# Altium schematic sheet Block Diagram.SchDoc("Block Diagram")
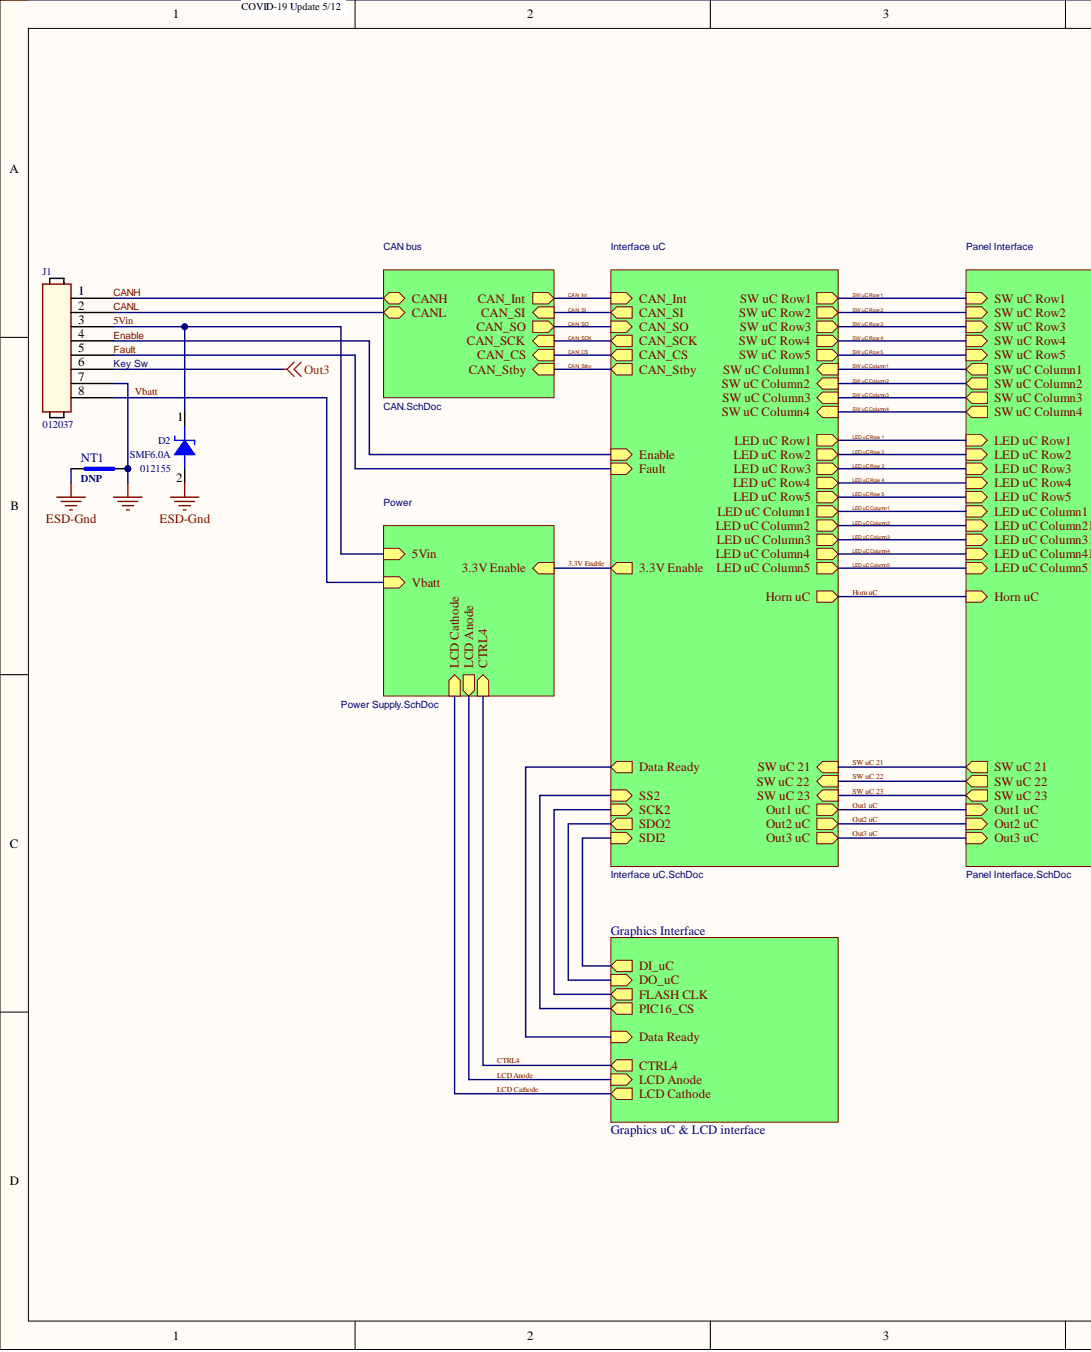
[diagram p0: Block Diagram.SchDoc, left]
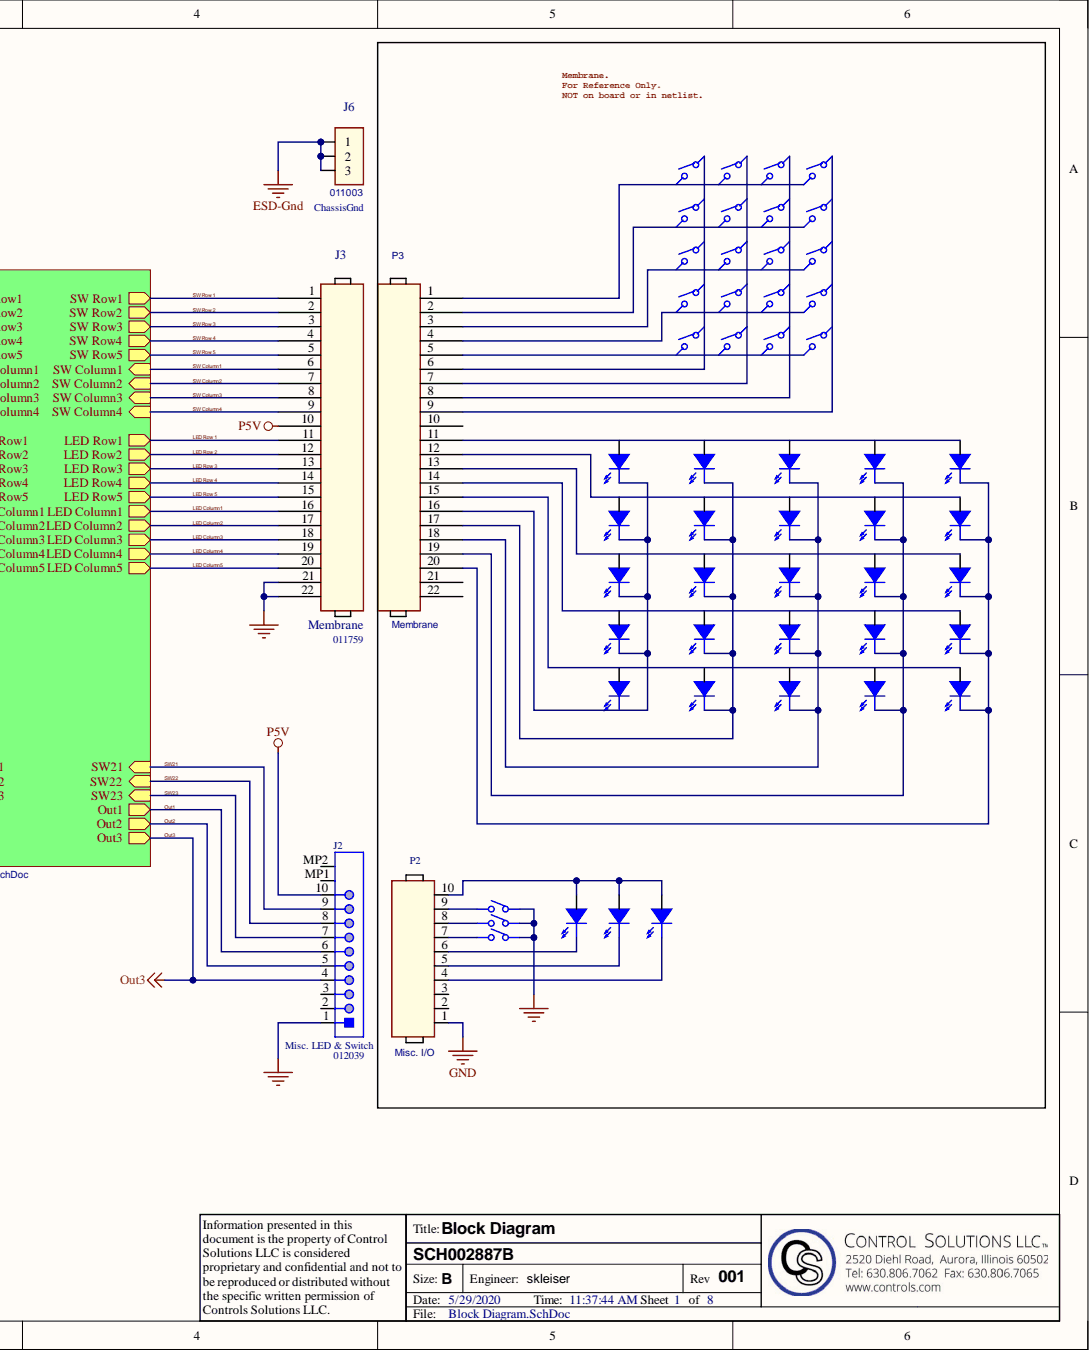
[diagram p1: Block Diagram.SchDoc, right]

## Parts
D2  SMF6.0A  [CS_IPC_SOD123_12]
J1  Controller  [B8B-PH-SM4-TB(LF)(SN)]  (value not drawn)
J2  Misc. LED & Switch  [B10B-PH-SM4-TB(LF)(SN)]
J3  Membrane  [MOLEX_522072233]
J6  ChassisGnd  [011003]
NT1  NOTE: DNP  [Res_20mil_short0603]  (hidden comment: Net_Tie_0603)

## Nets
ESD-Gnd: D2.2 J6.1 J6.2 J6.3 NT1.1
GND: J1.7 J2.1 J3.21 J3.22 NT1.2
P5V: J2.10 J3.10
5Vin: D2.1 J1.3
CANH: J1.1
CANL: J1.2
Enable: J1.4
Fault: J1.5
LED Column1: J3.16
LED Column2: J3.17
LED Column3: J3.18
LED Column4: J3.19
LED Column5: J3.20
LED Row1: J3.11
LED Row2: J3.12
LED Row3: J3.13
LED Row4: J3.14
LED Row5: J3.15
Out1: J2.6
Out2: J2.5
Out3: J1.6 J2.4
SW21: J2.9
SW22: J2.8
SW23: J2.7
SW Column1: J3.6
SW Column2: J3.7
SW Column3: J3.8
SW Column4: J3.9
SW Row1: J3.1
SW Row2: J3.2
SW Row3: J3.3
SW Row4: J3.4
SW Row5: J3.5
Vbatt: J1.8
NetJ2_2: J2.2
NetJ2_3: J2.3
NetJ2_MP1: J2.MP1
NetJ2_MP2: J2.MP2
3.3V Enable:
CAN_CS:
CAN_Int:
CAN_SCK:
CAN_SI:
CAN_SO:
CAN_Stby:
CTRL4:
Data Ready:
DI_uC:
DO_uC:
FLASH CLK:
Horn uC:
LCD Anode:
LCD Cathode:
LED uC Column1:
LED uC Column2:
LED uC Column3:
LED uC Column4:
LED uC Column5:
LED uC Row1:
LED uC Row2:
LED uC Row3:
LED uC Row4:
LED uC Row5:
Out1 uC:
Out2 uC:
Out3 uC:
PIC16_CS:
SW uC 21:
SW uC 22:
SW uC 23:
SW uC Column1:
SW uC Column2:
SW uC Column3:
SW uC Column4:
SW uC Row1:
SW uC Row2:
SW uC Row3:
SW uC Row4:
SW uC Row5:

## Library data of the parts
D2 = 012155: DIODE, TVS, 6V STANDOFF 7V BREAKDOWN 10.3V CLAMP 19.4A IPP SOD-123F RoHS; Manufacturer: Littelfuse Inc.; Database Table Name: parts; ID: 30164; Updated By: Engineer1; Component Kind: Standard; ComponentLink1Description: Datasheet; ComponentLink1URL: https://www.littelfuse.com/~/media/electronics/datasheets/tvs_diodes/littelfuse_tvs_diode_smf_datasheet.pdf.pdf; ComponentLink2Description: Supplier Part Details; ComponentLink2URL: https://www.digikey.com/products/en?keywords=F5749TR-ND; ComponentLink3Description: https://datasheet.ciiva.com/30478/0900766b81276b19-30478731.pdf?src-supplier=RSComponents; ComponentLink3URL: https://datasheet.ciiva.com/30478/0900766b81276b19-30478731.pdf?src-supplier=RSComponents; ComponentLink4Description: https://datasheet.ciiva.com/46435/1750558-46435717.pdf?src-supplier=Element14; ComponentLink4URL: https://datasheet.ciiva.com/46435/1750558-46435717.pdf?src-supplier=Element14; ComponentLink5Description: https://datasheet.ciiva.com/11193/smf5-0at1-d-11193433.pdf?src-supplier=Avnet; ComponentLink5URL: https://datasheet.ciiva.com/11193/smf5-0at1-d-11193433.pdf?src-supplier=Avnet; ComponentLink6Description: https://datasheet.ciiva.com/11194/smf5-0at1-d-11194649.pdf?src-supplier=Avnet; ComponentLink6URL: https://datasheet.ciiva.com/11194/smf5-0at1-d-11194649.pdf?src-supplier=Avnet; ComponentLink7Description: https://datasheet.ciiva.com/16487/smf5-0at1-d-16487982.pdf?src-supplier=Future+Electronics; ComponentLink7URL: https://datasheet.ciiva.com/16487/smf5-0at1-d-16487982.pdf?src-supplier=Future+Electronics; ComponentLink8Description: https://datasheet.ciiva.com/340/smf5-0at1-d-340017.pdf?src-supplier=Digi-Key; ComponentLink8URL: https://datasheet.ciiva.com/340/smf5-0at1-d-340017.pdf?src-supplier=Digi-Key; ComponentLink9Description: https://datasheet.ciiva.com/340/smf5-0at1-d-340015.pdf?src-supplier=Digi-Key; ComponentLink9URL: https://datasheet.ciiva.com/340/smf5-0at1-d-340015.pdf?src-supplier=Digi-Key; Created_Date: 2020/01/29 10:10:33; Current_Rating: 19.4A; LifeCycle: New; Manufacturer_Part_Number: SMF6.0A; MF: Littelfuse Inc.; Mfr Part Description: DIODE, TVS, 6V STANDOFF 7V BREAKDOWN 10.3V CLAMP 19.4A IPP SOD-123F ROHS; Mfr Part Number: SMF6.0A; Mfr. P/N: SMF6.0A; MP: SMF6.0A; Package_Case: SOD-123F; Part Number: 012155; Part_Type: Diode; RoHS: Yes; Sub_Type: TVS; Supplier: Digi-Key; Supplier 1: Digi-Key; Supplier Part Number: F5749TR-ND; Temperature Range: -55C to +150C (TJ); Updated_Date: 2020/04/16 11:11:00; Value: SMF6.0A; Voltage_Rating: 6V; Created By: Engineer1; Library Name: CSLLC.DbLib
J1 = 012037: Conn Header SMD Vertical 8Pos 2mm T/R RoHS; Manufacturer: JST Sales America Inc.; Manufacturer 1: JST; Mfr Part Description: CONN HEADER SMD 8POS 2MM; Part_Type: Connector; Connector Type: Male Pin; Contact Resistance: 20mR; Current Rating: 2A; Database Table Name: parts; Gender: Male; ID: 30145; Insulation Resistance: 1GR; Max Voltage Rating (AC): 100V; Number of Contacts: 8; Updated By: Engineer1; Value: B8B-PH-SM4-TB(LF)(SN); Color: Natural,White; Component Kind: Standard; ComponentLink1Description: Datasheet; ComponentLink1URL: http://www.jst-mfg.com/product/pdf/eng/ePH.pdf; ComponentLink2Description: Supplier Part Details; ComponentLink2URL: https://www.digikey.com/products/en?keywords=455-1740-2-ND; ComponentLink3Description: https://datasheet.ciiva.com/712/eph-712658.pdf?src-supplier=Digi-Key; ComponentLink3URL: https://datasheet.ciiva.com/712/eph-712658.pdf?src-supplier=Digi-Key; ComponentLink4Description: https://datasheet.ciiva.com/712/eph-712657.pdf?src-supplier=Digi-Key; ComponentLink4URL: https://datasheet.ciiva.com/712/eph-712657.pdf?src-supplier=Digi-Key; ComponentLink5Description: https://datasheet.ciiva.com/730/eph-730705.pdf?src-supplier=Digi-Key; ComponentLink5URL: https://datasheet.ciiva.com/730/eph-730705.pdf?src-supplier=Digi-Key; ComponentLink6Description: https://datasheet.ciiva.com/16288/eph-16288437.pdf?src-supplier=Future+Electronics; ComponentLink6URL: https://datasheet.ciiva.com/16288/eph-16288437.pdf?src-supplier=Future+Electronics; Contact Material: Tin,Bronze,Copper Alloy,Brass; Contact Mating Length: 0.13inch; Contact Plating: Tin,Copper; Created_Date: 2019/10/15 13:20:55; Current_Rating: 2A; Depth: 5mm; Fastening Type: Detent; Flammability Rating: UL94 V-0; Height: 9.4mm; Housing Color: Beige; Housing Material: Polyamide; Lead Free: Lead Free; Lead Pitch: 2mm; Length: 19.95mm; LifeCycle: New; Manufacturer Part Number 1: B8B-PH-SM4-TB(LF)(SN); Max Current Rating: 2A; Max Operating Temperature: 85°C; Mfr Part Number: B8B-PH-SM4-TB(LF)(SN); Mfr. P/N: B8B-PH-SM4-TB(LF)(SN); Min Operating Temperature: -25°C; Mount: Surface Mount; Number of Poles: 8; Number of Positions: 8; Number of Rows: 1; Orientation: Straight; Packaging: Tape and Reel; Part Number: 012037; Pitch: 2mm; Pricing 1: 1=0.28900, 10=0.28900, 25=0.28900, 50=0.28900, 100=0.28900, 250=0.28900, 500=0.28900, 1000=0.28900 (USD); REACH SVHC: No SVHC; RoHS: Yes; Stock 1: 6123; Supplier: Digi-Key; Supplier 1: Newark; Supplier Part Number: 455-1740-2-ND; Supplier Part Number 1: 38K0010; Temperature Range: -25C to +85C; Termination: Solder; Updated_Date: 2019/10/24 09:56:43; Voltage Rating: 100V; Voltage Rating (AC): 100V; Voltage_Rating: 100V; Width: 5.5mm; Working Voltage: 100V; Created By: Engineer1; Library Name: CSLLC.DbLib
J2 = 012039: CONN HEADER SMD 10POS 2MM; Manufacturer: JST Sales America Inc.; Mfr Part Description: Connector Header Surface Mount 10 position 0.079" (2.00mm); Part_Type: Connector; Current_Rating: 2A; Database Table Name: parts; ID: 30146; Updated By: Engineer1; Value: B10B-PH-SM4-TB(LF)(SN); Voltage_Rating: 100V; Component Kind: Standard; ComponentLink1Description: Datasheet; ComponentLink2Description: Supplier Part Details; ComponentLink2URL: https://www.digikey.com/products/en?keywords=455-1742-2-ND; Created_Date: 2019/10/15 15:44:27; LifeCycle: New; Mfr Part Number: B10B-PH-SM4-TB(LF)(SN); Mfr. P/N: B10B-PH-SM4-TB(LF)(SN); Part Number: 012039; RoHS: Yes; Supplier: Digi-Key; Supplier Part Number: 455-1742-2-ND; Temperature Range: -25C to +85C; Updated_Date: 2019/10/24 10:06:21; Created By: Engineer1; Library Name: CSLLC.DbLib
J3 = 011759: Manufacturer: Molex; Mfr Part Description: CONN FFC FPC TOP 22POS 1MM R/A; Mfr Part Number: 0522072233; Part_Type: Connector; Current_Rating: 1A; Database Table Name: parts; ID: 30098; Updated By: Engineer1; Value: 0522072233; Voltage_Rating: 125V; Component Kind: Standard; ComponentLink1Description: Datasheet; ComponentLink1URL: https://www.molex.com/pdm_docs/sd/522070833_sd.pdf; ComponentLink2Description: Supplier Part Details; ComponentLink2URL: https://www.digikey.com/products/en/connectors-interconnects/ffc-fpc-flat-flexible-connectors/399?k=522072233&k=&pkeyword=522072233&sv=0&pv7=1&sf=0&quantity=&ColumnSort=0&page=1&pageSize=25; Created_Date: 2019/07/26 09:00:58; LifeCycle: New; Mfr. P/N: 0522072233; Part Number: 011759; RoHS: Yes; Supplier: Digi-Key; Supplier Part Number: WM10956TR-ND; Temperature Range: -40C to +85C; Updated_Date: 2019/07/26 09:03:48; Created By: Engineer1; Library Name: CSLLC.DbLib
J6 = 011003: Manufacturer: Zierick; Mfr. P/N: 1278; Part_Type: Connector; Current_Rating: 20A; Database Table Name: parts; ID: 30038; Updated By: bglase; Component Kind: Standard; ComponentLink1Description: Datasheet; ComponentLink1URL: http://www.zierick.com/pdf/ap1278.pdf; ComponentLink2Description: Supplier Part Details; Created_Date: 2019/04/09 16:36:54; LifeCycle: New; Part Number: 011003; RoHS: Yes; Supplier Part Number: 1278; Updated_Date: 2019/04/10 22:41:24; Created By: bglase; Library Name: CSLLC.DbLib
NT1 = 012231: 0603 DNP resistor with 35mil pad-to-pad shorting trace; Mfr Part Description: This is an 0603 net tie. No part is needed.; Mfr Part Number: None; Database Table Name: parts; ID: 30171; Updated By: Engineer1; Value: 0603 shorted; Component Kind: Net Tie; ComponentLink1Description: Datasheet; ComponentLink2Description: Supplier Part Details; Created_Date: 2020/04/01 14:42:17; Current_Rating: N/A; Dielectric: N/A; LifeCycle: New; Package_Case: 0603; Part Number: 012231; Power_Rating: N/A; RoHS: Yes; Temperature Coeff: N/A; Temperature Range: N/A; Updated_Date: 2020/05/04 17:18:31; Voltage_Rating: N/A; Created By: Engineer1; Library Name: CSLLC.DbLib
